# Altium schematic sheet LoRa.SchDoc
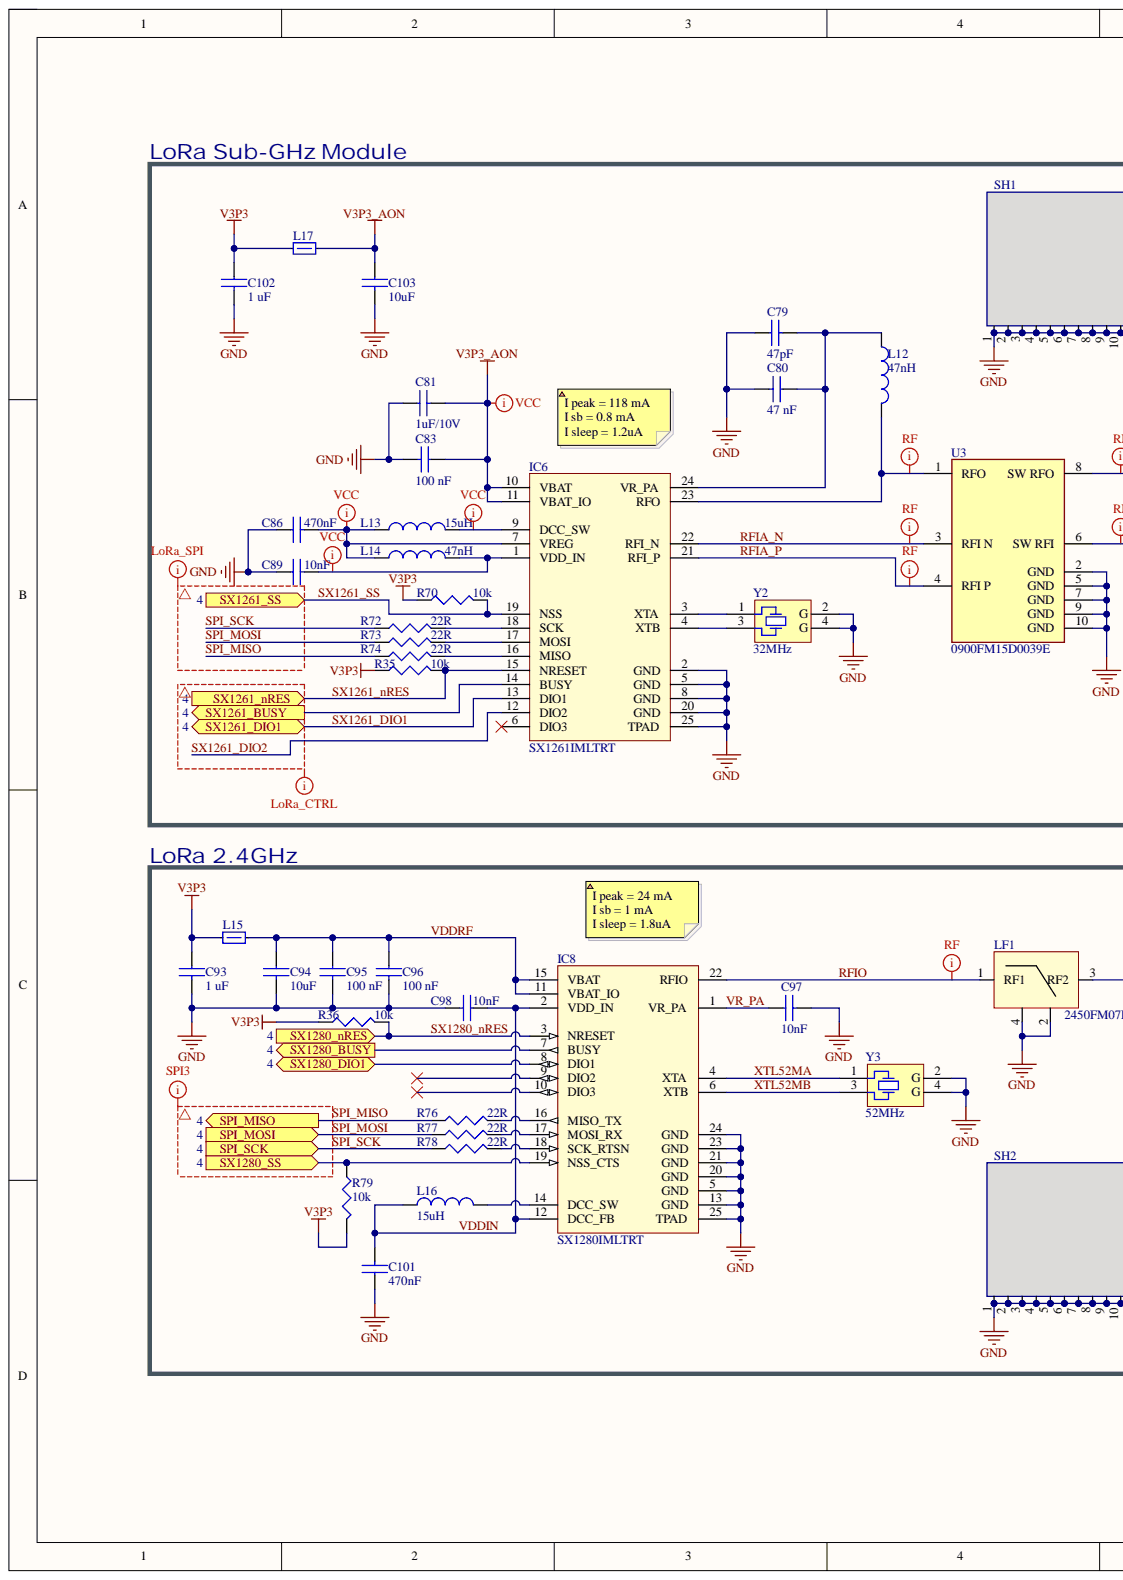
[diagram p0: LoRa.SchDoc, left]
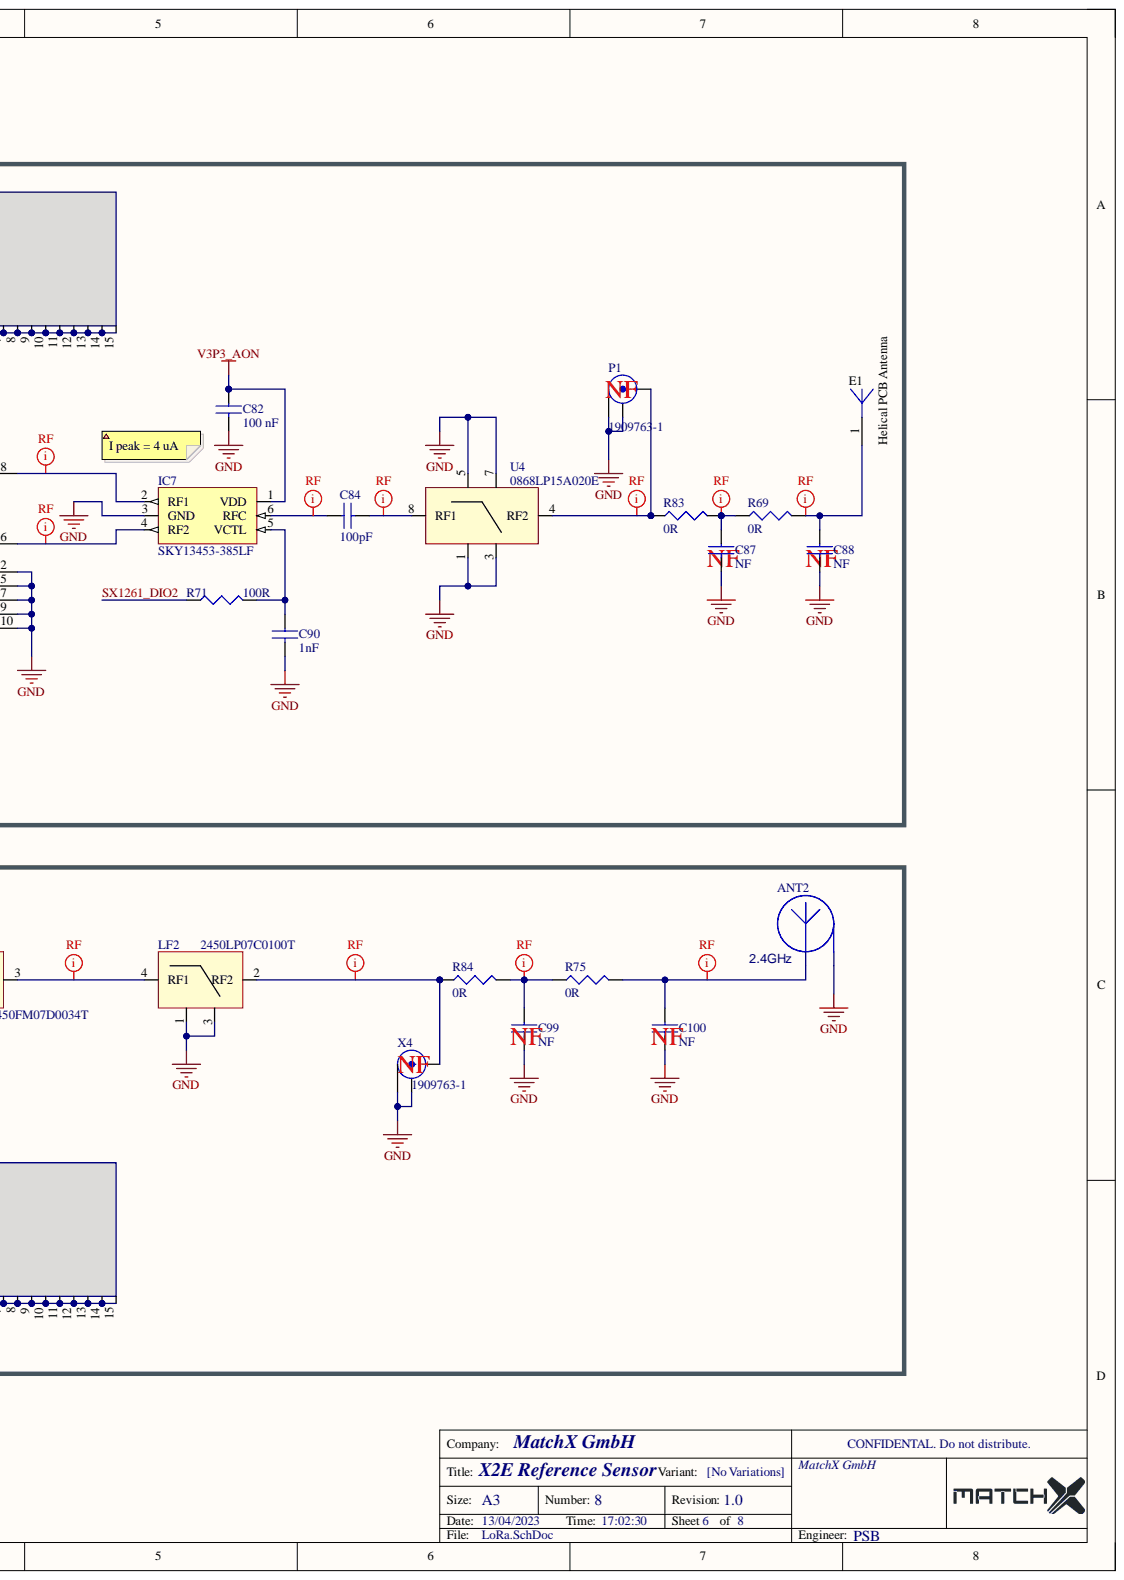
[diagram p1: LoRa.SchDoc, right]

## Parts
ANT2  2.4GHz  [ANT_INVERTED_F]
C79  47pF  [C0402]
C80  47 nF  [C0402]
C81  1uF/10V  [C0402]
C82  100 nF  [C0402]
C83  100 nF  [C0402]
C84  100pF  [C0402]
C86  470nF  [C0402]
C87  NF  [C0402]
C88  NF  [C0402]
C89  10nF  [C0402]
C90  1nF  [C0402]
C93  1 uF  [C0603]
C94  10uF  [C0805]
C95  100 nF  [C0402]
C96  100 nF  [C0402]
C97  10nF  [C0402]
C98  10nF  [C0402]
C99  NF  [C0402]
C100  NF  [C0402]
C101  470nF  [C0402]
C102  1 uF  [C0603]
C103  10uF  [C0805]
E1  Helical PCB Antenna  CompType: Antenna  [ANTENNA_HELICAL]
IC6  SX1261IMLTRT  [QFN50P400X400X80_HS-25N_Semtech]
IC7  SKY13453-385LF  [QFN50P100X100X50-6N]
IC8  SX1280IMLTRT  [QFN50P400X400X80_HS-25N_Semtech]
L12  47nH  [L0402]
L13  15uH  [L0805_ML]
L14  47nH  [L0402]
L15  BLM18KG601SH1D  [L0603]  (value not drawn)
L16  15uH  [L0805_ML]
L17  BLM18KG601SH1D  [L0603]  (value not drawn)
LF1  2450FM07D0034T  [JT=2450FM07D0034T]
LF2  2450LP07C0100T  [JT=2450LP07C0100T]
P1  1909763-1  [CON_U.FL]
R35  10k  [R0402]
R36  10k  [R0402]
R69  0R  .Checked SCH: RF  [R0402]
R70  10k  [R0402]
R71  100R  [R0402]
R72  22R  [R0402]
R73  22R  [R0402]
R74  22R  [R0402]
R75  0R  [R0402]
R76  22R  [R0402]
R77  22R  [R0402]
R78  22R  [R0402]
R79  10k  [R0402]
R83  0R  .Checked SCH: RF  [R0402]
R84  0R  .Checked SCH: RF  [R0402]
SH1  Logo symbol  [SHIELD_MSTICK_SOM]  (value not drawn)
SH2  Logo symbol  [SHIELD_MSTICK_SOM]  (value not drawn)
U3  0900FM15D0039E  [FP-0900FM15D0039E-MFG]
U4  0868LP15A020E  [LP_FILTER_JOHANSON]
X4  1909763-1  [CON_U.FL]
Y2  32MHz  [nd2016da]
Y3  52MHz  [nd2016da]

## Ports
SPI_MISO, SPI_MOSI, SPI_SCK, SX1261_BUSY, SX1261_DIO1, SX1261_nRES, SX1261_SS, SX1280_BUSY, SX1280_DIO1, SX1280_nRES, SX1280_SS

## Nets
GND: ANT2.GND C79.1 C80.2 C81.1 C82.2 C83.2 C86.1 C87.2 C88.2 C89.1 C90.2 C93.2 C94.2 C95.2 C96.2 C97.2 C98.2 C99.2 C100.2 C101.1 C102.2 C103.2 IC6.2(GND) IC6.5(GND) IC6.8(GND) IC6.20(GND) IC6.25(TPAD) IC7.3(GND) IC8.5(GND) IC8.13(GND) IC8.20(GND) IC8.21(GND) IC8.23(GND) IC8.24(GND) IC8.25(TPAD) LF1.2 LF1.4 LF2.1 LF2.3 P1.2 P1.3 SH1.1 SH1.2 SH1.3 SH1.4 SH1.5 SH1.6 SH1.7 SH1.8 SH1.9 SH1.10 SH1.11 SH1.12 SH1.13 SH1.14 SH1.15 SH2.1 SH2.2 SH2.3 SH2.4 SH2.5 SH2.6 SH2.7 SH2.8 SH2.9 SH2.10 SH2.11 SH2.12 SH2.13 SH2.14 SH2.15 U3.2(GND) U3.5(GND) U3.7(GND) U3.9(GND) U3.10(GND) U4.1 U4.3 U4.5 U4.7 X4.2 X4.3 Y2.2(G) Y2.4(G) Y3.2(G) Y3.4(G)
V3P3: C93.1 C102.1 L15.1 L17.1 R35.1 R36.1 R70.1 R79.2
V3P3_AON: C81.2 C82.1 C83.1 C103.1 IC6.10(VBAT) IC6.11(VBAT_IO) IC7.1(VDD) L17.2
RFIA_N: IC6.22(RFI_N) U3.3(RFI_N)
RFIA_P: IC6.21(RFI_P) U3.4(RFI_P)
RFIO: IC8.22(RFIO) LF1.1(RF1)
SPI_MISO: R74.1 R76.1 ; port SPI_MISO
SPI_MOSI: R73.1 R77.1 ; port SPI_MOSI
SPI_SCK: R72.1 R78.1 ; port SPI_SCK
SX1261_BUSY: IC6.14(BUSY) ; port SX1261_BUSY
SX1261_DIO1: IC6.13(DIO1) ; port SX1261_DIO1
SX1261_DIO2: IC6.12(DIO2) R71.1
SX1261_nRES: IC6.15(NRESET) R35.2 ; port SX1261_nRES
SX1261_SS: IC6.19(NSS) R70.2 ; port SX1261_SS
SX1280_BUSY: IC8.7(BUSY) ; port SX1280_BUSY
SX1280_DIO1: IC8.8(DIO1) ; port SX1280_DIO1
SX1280_nRES: IC8.3(NRESET) R36.2 ; port SX1280_nRES
SX1280_SS: IC8.19(NSS_CTS) R79.1 ; port SX1280_SS
VDDIN: C98.1 C101.2 IC8.2(VDD_IN) IC8.12(DCC_FB) L16.1
VDDRF: C94.1 C95.1 C96.1 IC8.11(VBAT_IO) IC8.15(VBAT) L15.2
VR_PA: C97.1 IC8.1(VR_PA)
XTL52MA: IC8.4(XTA) Y3.1
XTL52MB: IC8.6(XTB) Y3.3
NetANT2_RF: ANT2.RF C100.1 R75.2
NetC79_2: C79.2 C80.1 IC6.24(VR_PA) L12.1
NetC84_1: C84.1 IC7.6(RFC)
NetC84_2: C84.2 U4.8(RF1)
NetC86_2: C86.2 IC6.7(VREG) L13.1 L14.1
NetC87_1: C87.1 R69.1 R83.2
NetC88_1: C88.1 E1.1 R69.2
NetC89_2: C89.2 IC6.1(VDD_IN) L14.2
NetC90_1: C90.1 IC7.5(VCTL) R71.2
NetC99_1: C99.1 R75.1 R84.2
NetIC6_3: IC6.3(XTA) Y2.1
NetIC6_4: IC6.4(XTB) Y2.3
NetIC6_9: IC6.9(DCC_SW) L13.2
NetIC6_16: IC6.16(MISO) R74.2
NetIC6_17: IC6.17(MOSI) R73.2
NetIC6_18: IC6.18(SCK) R72.2
NetIC6_23: IC6.23(RFO) L12.2 U3.1(RFO)
NetIC7_2: IC7.2(RF1) U3.8(SW_RFO)
NetIC7_4: IC7.4(RF2) U3.6(SW_RFI)
NetIC8_14: IC8.14(DCC_SW) L16.2
NetIC8_16: IC8.16(MISO_TX) R76.2
NetIC8_17: IC8.17(MOSI_RX) R77.2
NetIC8_18: IC8.18(SCK_RTSN) R78.2
NetLF1_3: LF1.3(RF2) LF2.4(RF1)
NetLF2_2: LF2.2(RF2) R84.1 X4.1
NetP1_1: P1.1 R83.1 U4.4(RF2)

Not connected: IC6 6(DIO3); IC8 9(DIO2) 10(DIO3)

## Library data of the parts
ANT2 = Antenna_IFA_PCB_2.4GHz: Antennas 2.45GHz PCB Meandered; Manufacturer: NA; Manufacturer Part Number: No Part, PCB antenna; Frequency Group: UHF (2 GHz ~ 3 GHz); Frequency Range: 2.408GHz ~ 2.492GHz; Antenna Type: PCB; Applications: Bluetooth, WLAN, Zigbee™; Category: RF/IF and RFID; Component Kind: Net Tie; Features: -; Frequency (Center/Band): 2.4GHz; Height (Max): 0; Ingress Protection: -; Package / Case: PCB; Termination: Solder; Value: 2.4GHz; VSWR: -; Library Name: psblib.SCHLIB
C79 = C0402-47pF: CAP, CERM, 47pF, 16V, +/- 5%, C0G/NP0, 0402; CompType: Capacitor; Category: Capacitors; Component Kind: Standard; MountType: SMT; Operating Temperature: -55°C ~ 125°C; Package / Case: 0402; RoHS: RoHS Compliant; Technology: Ceramic; Temperature Coefficient: C0G/NP0; Tolerance: 5%; Value: 47pF; Voltage - Rated: 16 V; Library Name: psblib.SCHLIB
C80 = C0402-47nF: CAP, CERM, 47nF, 16V, +/- 10%, X7R, 0402; CompType: Capacitor; Category: Capacitors; Component Kind: Standard; MountType: SMT; Operating Temperature: -55°C ~ 125°C; Package / Case: 0402; RoHS: RoHS Compliant; Technology: Ceramic; Temperature Coefficient: X7R; Tolerance: 10%; Value: 47 nF; Voltage - Rated: 16 V; Library Name: psblib.SCHLIB
C81 = C0402-1uF_10V: CAP, CERM, 1 uF, 10V, +/- 10%, X5R, 0402; CompType: Capacitor; Category: Capacitors; Component Kind: Standard; MountType: SMT; Operating Temperature: -55°C ~ 85°C; Package / Case: 0402; RoHS: RoHS Compliant; Technology: Ceramic; Temperature Coefficient: X5R; Tolerance: 10%; Value: 1uF/10V; Voltage - Rated: 10V; Library Name: psblib.SCHLIB
C82 C83 C95 C96 = C0402-100nF_50V: CAP, CERM, 100 nF, 50V, +/- 10%, X7R, 0402; CompType: Capacitor; Category: Capacitors; Component Kind: Standard; MountType: SMT; Operating Temperature: -55°C ~ 125°C; Package / Case: 0402; RoHS: RoHS Compliant; Technology: Ceramic; Temperature Coefficient: X7R; Tolerance: 10%; Value: 100 nF; Voltage - Rated: 50 V; Library Name: psblib.SCHLIB
C84 = C0402-100pF: CAP, CERM, 100pF, 16V, +/- 5%, C0G/NP0, 0402; CompType: Capacitor; Category: Capacitors; Component Kind: Standard; MountType: SMT; Operating Temperature: -55°C ~ 125°C; Package / Case: 0402; RoHS: RoHS Compliant; Technology: Ceramic; Temperature Coefficient: C0G/NP0; Tolerance: 5%; Value: 100pF; Voltage - Rated: 16 V; Library Name: psblib.SCHLIB
C86 C101 = C0402-470nF: CAP, CERM, 470nF, 16V, +/- 10%, X7R, 0402; CompType: Capacitor; Category: Capacitors; Component Kind: Standard; MountType: SMT; Operating Temperature: -55°C ~ 125°C; Package / Case: 0402; RoHS: RoHS Compliant; Technology: Ceramic; Temperature Coefficient: X7R; Tolerance: 10%; Value: 470nF; Voltage - Rated: 16 V; Library Name: psblib.SCHLIB
C87 C88 C99 C100 = C0402-1.8pF: CAP, CERM, 1.8pF, 50V, +/- 0.1pF, C0G/NP0, 0402; CompType: Capacitor; Category: Capacitors; Component Kind: Standard; MountType: SMT; Operating Temperature: -55°C ~ 125°C; Package / Case: 0402; RoHS: RoHS Compliant; Technology: Ceramic; Temperature Coefficient: C0G/NP0; Tolerance: +/- 0.1pF; Value: NF; Voltage - Rated: 50V; Library Name: psblib.SCHLIB
C89 C97 C98 = C0402-10nF: CAP, CERM, 10nF, 16V, +/- 10%, X7R, 0402; CompType: Capacitor; Category: Capacitors; Component Kind: Standard; MountType: SMT; Operating Temperature: -55°C ~ 125°C; Package / Case: 0402; RoHS: RoHS Compliant; Technology: Ceramic; Temperature Coefficient: X7R; Tolerance: 10%; Value: 10nF; Voltage - Rated: 16 V; Library Name: psblib.SCHLIB
C90 = C0402-1nF: CAP, CERM, 1nF, 16V, +/- 10%, X7R, 0402; CompType: Capacitor; Category: Capacitors; Component Kind: Standard; MountType: SMT; Operating Temperature: -55°C ~ 125°C; Package / Case: 0402; RoHS: RoHS Compliant; Technology: Ceramic; Temperature Coefficient: X7R; Tolerance: 10%; Value: 1nF; Voltage - Rated: 16 V; Library Name: psblib.SCHLIB
C93 C102 = C0603-1uF_25V: CAP, CERM, 1 uF, 25 V, +/- 10%, X7R, 0603; CompType: Capacitor; Voltage - Rated: 25 V; Category: Capacitors; Component Kind: Standard; MountType: SMT; Operating Temperature: -55°C ~ 125°C; Package / Case: 0603 (1608 Metric); RoHS: RoHS Compliant; Technology: Ceramic; Temperature Coefficient: X7R; Tolerance: 10%; Value: 1 uF; Library Name: psblib.SCHLIB
C94 C103 = C0805-10uF_16V: CAP, CERM, 10uF, 16V, +/- 20%, X5R, 0805; CompType: Capacitor; Category: Capacitors; Component Kind: Standard; MountType: SMT; Operating Temperature: -55°C ~ 85°C; Package / Case: 0805 (2012 Metric); RoHS: RoHS Compliant; Technology: Ceramic; Temperature Coefficient: X5R; Tolerance: 20%; Value: 10uF; Voltage - Rated: 16 V; Library Name: psblib.SCHLIB
E1 = ANTENNA_HELICAL: PCB Antenna. There is nothing to buy or mount.; Manufacturer Part Number: PCB Antenna, No Part; SymbolCreator: Altium; Component Kind: Net Tie; ComponentLink1Description: Datasheet Link; ComponentLink1URL: http://www.ti.com/lit/an/swra416/swra416.pdf; LatestRevisionDate: 2015-04-23; Manufacturer: N/A; MountType: N/A; PackageReference: Antenna; PartNumber: Helical PCB Antenna; SymbolVersion: 2015-04-22; Library Name: psblib.SCHLIB; Publisher: Texas Instruments
IC6 = SX1261IMLTRT: IC RF TxRx Only General ISM < 1GHz LoRaWAN 150MHz ~ 960MHz 24-VFQFN Exposed Pad; Manufacturer: Semtech; Component Kind: Standard; ComponentLink1Description: https://www.semtech.com/uploads/documents/DS_SX1261-2_V1.1.pdf?src-supplier=Digi-Key; ComponentLink1URL: https://www.semtech.com/uploads/documents/DS_SX1261-2_V1.1.pdf?src-supplier=Digi-Key; Manufacturer Part Number: SX1261IMLTRT; Pricing 1: 1 = 7,53000, 10 = 6,90700, 25 = 6,27920, 100 = 5,65110, 250 = 5,18016, 500 = 4,70926, 1000 = 4,23833 (USD); RoHS: No; Stock 1: 1035; Supplier 1: Digi-Key; Supplier Part Number 1: SX1261IMLTRTCT-ND; Library Name: psblib.SCHLIB
IC7 = SKY13453-385LF: RF Switch IC WLAN 6GHz 50 Ohm 6-QFN (1x1); Manufacturer: Skyworks Solutions; IIP3: 57dBm; Impedance: 50R; Max Frequency: 600MHz; Operating Supply Voltage: 3.5V; P1dB: 33dBm; Case/Package: QFN; Component Kind: Standard; ComponentLink1Description: http://www.mouser.com/ds/2/472/SKY13453_385LF_202830B-437415.pdf?src-supplier=Mouser; ComponentLink1URL: http://www.mouser.com/ds/2/472/SKY13453_385LF_202830B-437415.pdf?src-supplier=Mouser; ComponentLink2Description: http://www.skyworksinc.com/uploads/documents/SKY13453_385LF_202830C.pdf?src-supplier=Avnet+Asia; ComponentLink2URL: http://www.skyworksinc.com/uploads/documents/SKY13453_385LF_202830C.pdf?src-supplier=Avnet+Asia; ComponentLink3Description: http://www.skyworksinc.com/uploads/documents/SKY13453_385LF_202830E.pdf?src-supplier=Digi-Key; ComponentLink3URL: http://www.skyworksinc.com/uploads/documents/SKY13453_385LF_202830E.pdf?src-supplier=Digi-Key; ComponentLink4Description: http://www.skyworksinc.com/uploads/documents/SKY13453_385LF_202830C.pdf?src-supplier=Avnet; ComponentLink4URL: http://www.skyworksinc.com/uploads/documents/SKY13453_385LF_202830C.pdf?src-supplier=Avnet; Manufacturer Part Number: SKY13453-385LF; Max Operating Temperature: 90°C; Min Operating Temperature: -30°C; Mount: Surface Mount; Number of Pins: 6; Packaging: Tape and Reel; Pricing 1: 1 = 0,63000, 10 = 0,55700, 100 = 0,42740, 500 = 0,33784, 1000 = 0,27027 (USD); RoHS: Yes; Stock 1: 46443; Supplier 1: Digi-Key; Supplier Part Number 1: 863-1602-1-ND; Library Name: MatchX_Integrated_Library.IntLib
IC8 = SX1280IMLTRT: IC RF TxRx Only 802.15.4 LoRa™ 2.4GHz 24-WFQFN Exposed Pad; Manufacturer: Semtech; Component Kind: Standard; ComponentLink1Description: https://www.semtech.com/uploads/documents/DS_SX1280-1_V2.2.pdf?src-supplier=Digi-Key; ComponentLink1URL: https://www.semtech.com/uploads/documents/DS_SX1280-1_V2.2.pdf?src-supplier=Digi-Key; Manufacturer Part Number: SX1280IMLTRT; Pricing 1: 1=6,93000, 10=6,35300, 25=5,77520, 100=5,19750, 250=4,76436, 500=4,33126, 1000=3,89813 (USD); RoHS: No; Stock 1: 1571; Supplier 1: Digi-Key; Supplier Part Number 1: SX1280IMLTRTCT-ND; Library Name: psblib.SCHLIB
L12 L14 = LQW15AN47NJ00D: Inductor, Wirewound, 47nH, 210 mA, 1.08Ohms, ±0.2nH, SMD; Manufacturer: Murata; Core Material: Air; Current Rating: 210mA; DC Resistance (DCR): 1.08R; Q Factor: 25; Self Resonant Frequency: 2.9GHz; Shielding: Unshielded; Test Frequency: 100MHz; Tolerance: 5%; Case Code (Imperial): 0402; Case Code (Metric): 1005; Case/Package: 0402; Component Kind: Standard; ComponentLink1Description: https://datasheet.ciiva.com/11799/0900766b8090d800-11799049.pdf?src-supplier=RSComponents; ComponentLink1URL: https://datasheet.ciiva.com/11799/0900766b8090d800-11799049.pdf?src-supplier=RSComponents; ComponentLink2Description: https://datasheet.ciiva.com/1042/l0075s0080lqw15a-00-1042160.pdf?src-supplier=Digi-Key; ComponentLink2URL: https://datasheet.ciiva.com/1042/l0075s0080lqw15a-00-1042160.pdf?src-supplier=Digi-Key; ComponentLink3Description: https://datasheet.ciiva.com/1042/l0075s0080lqw15a-00-1042161.pdf?src-supplier=Digi-Key; ComponentLink3URL: https://datasheet.ciiva.com/1042/l0075s0080lqw15a-00-1042161.pdf?src-supplier=Digi-Key; ComponentLink4Description: https://datasheet.ciiva.com/8506/l0075s0080lqw15a-00-8506009.pdf?src-supplier=Avnet; ComponentLink4URL: https://datasheet.ciiva.com/8506/l0075s0080lqw15a-00-8506009.pdf?src-supplier=Avnet; ComponentLink5Description: https://datasheet.ciiva.com/17247/l0075s0080lqw15a-00-17247726.pdf?src-supplier=Avnet+Europe; ComponentLink5URL: https://datasheet.ciiva.com/17247/l0075s0080lqw15a-00-17247726.pdf?src-supplier=Avnet+Europe; ComponentLink6Description: https://datasheet.ciiva.com/1042/l0075s0080lqw15a-00-1042159.pdf?src-supplier=Digi-Key; ComponentLink6URL: https://datasheet.ciiva.com/1042/l0075s0080lqw15a-00-1042159.pdf?src-supplier=Digi-Key; Composition: Wirewound; DC Current: 210mA; Depth: 0.6mm; Height: 0.5mm; Inductance: 47nH; Lead Free: Lead Free; Length: 1mm; Manufacturer Part Number: LQW15AN47NJ00D; Max DC Current: 210mA; Max Operating Temperature: 125°C; Military Standard: Not; Min Operating Temperature: -55°C; Mount: Surface Mount; Package Quantity: 1; Packaging: Tape and Reel; Pricing 1: 1 = 0,17000, 10 = 0,15500, 100 = 0,10350, 500 = 0,08626, 1000 = 0,07245, 2500 = 0,06210 (USD); Radiation Hardening: No; RoHS: Yes; Signal Integrity: Inductor; Simulation: Inductor; Stock 1: 62730; Supplier 1: Digi-Key; Supplier Part Number 1: 490-1154-1-ND; Termination: SMD/SMT; Value: 47nH; Weight: 2.8E-05oz; Width: 0.02inch; Library Name: psblib.SCHLIB
L13 = L_LQM21DN150M70L: Inductor, 15Uh, 0.25A, Multilayer Rohs Compliant: Yes; Manufacturer: Murata; Category: Fixed Inductors; Component Kind: Standard; Manufacturer Part Number: LQM21DN150M70L; Pricing 1: 1=0,26000, 10=0,23700, 25=0,21040, 50=0,17880, 100=0,15770, 250=0,14460, 500=0,13144, 1000=0,11042 (USD); RoHS: Yes; Stock 1: 2488; Supplier 1: Digi-Key; Supplier Part Number 1: 490-LQM21DN150M70LCT-ND; Value: 15uH; Library Name: psblib.SCHLIB
L15 L17 = BLM18KG601SH1D: Ferrite Beads 0603 600ohms 1.3A DCR=.15ohms; Manufacturer: Murata Electronics North America; DC Resistance (DCR) (Max): 150 mOhm; Filter Type: Power Line; Impedance @ Frequency: 600 Ohm @ 100MHz; Number of Lines: 1; Category: Filters; Component Kind: Standard; ComponentLink1Description: http://www.murata.com/en-global/products/productdata/8796737273886/QNFA9101.pdf; ComponentLink1URL: http://www.murata.com/en-global/products/productdata/8796737273886/QNFA9101.pdf; Current Rating (Max): 1.3A; Height (Max): 0.037" (0.95mm); Manufacturer Part Number: BLM18KG601SH1D; Mounting Type: Surface Mount; Operating Temperature: -55°C ~ 125°C; Package / Case: 0603 (1608 Metric); Packaging: Cut Tape (CT); Pricing 1: 1=0.11, 10=0.088, 50=0.058, 100=0.0502, 250=0.0396, 500=0.03344, 1000=0.02816 (USD); Ratings: AEC-Q200; RoHS: RoHS Compliant; Size / Dimension: 0.063" L x 0.032" W (1.60mm x 0.80mm); Stock 1: 5830; Supplier 1: Digi-Key; Supplier Part Number 1: 490-13259-1-ND; Library Name: psblib.SCHLIB
L16 = L_MLZ2012M150WTD25: Chip inductor, 15uH, 250mA, 950mOhm, 0805; Manufacturer: TDK; Core Material: Ferrite; DC Resistance (DCR): 1.235R; Saturation Current: 120mA; Shielding: Shielded; Test Frequency: 2MHz; Tolerance: 20%; Case Code (Imperial): 0805; Case Code (Metric): 2012; Case/Package: 0805; Component Kind: Standard; ComponentLink1Description: http://www.mouser.com/ds/2/400/nductor_commercial_decoupling_mlz2012_en-918301.pdf?src-supplier=Mouser; ComponentLink1URL: http://www.mouser.com/ds/2/400/nductor_commercial_decoupling_mlz2012_en-918301.pdf?src-supplier=Mouser; ComponentLink2Description: http://product.tdk.com/inductor/ind/en/documents/inductor_automotive_decoupling_mlz_en.pdf?src-supplier=Digi-Key; ComponentLink2URL: http://product.tdk.com/inductor/ind/en/documents/inductor_automotive_decoupling_mlz_en.pdf?src-supplier=Digi-Key; ComponentLink3Description: https://product.tdk.com/info/en/catalog/datasheets/inductor_automotive_decoupling_mlz2012_en.pdf?src-supplier=Digi-Key; ComponentLink3URL: https://product.tdk.com/info/en/catalog/datasheets/inductor_automotive_decoupling_mlz2012_en.pdf?src-supplier=Digi-Key; Current Rating: 250mA; Height: 1.25mm; Height - Seated (Max): 0.057inch; Inductance: 15uH; Length: 0.079inch; Manufacturer Part Number: MLZ2012M150WTD25; Max DC Current: 250mA; Max Operating Temperature: 125°C; Min Operating Temperature: -55°C; Mount: Surface Mount; Package Quantity: 2000; Packaging: Tape and Reel; Pricing 1: 1 = 0,19000, 10 = 0,16800, 100 = 0,11210, 500 = 0,09346, 1000 = 0,07850 (USD); Ratings: AEC-Q200; RoHS: Yes; Stock 1: 1570; Supplier 1: Digi-Key; Supplier Part Number 1: 445-17074-1-ND; Termination: SMD/SMT; Value: 15uH; Weight: 0.000194oz; Width: 0.049inch; Library Name: psblib.SCHLIB
LF1 = JT=2450FM07D0034T: Rf Filter 2.4GHZ-2.5GHZ 0402; Manufacturer: Johanson; Bandwidth: 100MHz; Frequency: 2.5GHz; Insertion Loss: 0.75dB; Case Code (Imperial): 0402; Case Code (Metric): 1005; Component Kind: Standard; ComponentLink1Description: https://www.johansontechnology.com/datasheets/2450FM07D0034/2450FM07D0034.pdf?src-supplier=Digi-Key; ComponentLink1URL: https://www.johansontechnology.com/datasheets/2450FM07D0034/2450FM07D0034.pdf?src-supplier=Digi-Key; Manufacturer Part Number: 2450FM07D0034T; Mount: Surface Mount; Packaging: Tape and Reel; Pricing 1: 1=0,28000, 10=0,24400, 25=0,22080, 100=0,19310, 250=0,16944, 500=0,14972, 1000=0,11820 (USD); RoHS: Yes; RoHS Compliant: No; Stock 1: 116294; Supplier 1: Digi-Key; Supplier Part Number 1: 712-1654-1-ND; Library Name: psblib.SCHLIB
LF2 = JT=2450LP07C0100T: Rf Filter Low Pass 2.4GHZ 0402; Manufacturer: Johanson; Component Kind: Standard; Manufacturer Part Number: 2450LP07C0100T; Pricing 1: 1=0,29000, 10=0,26900, 25=0,25640, 50=0,24800, 100=0,23980, 250=0,22736, 500=0,19016, 1000=0,14758, 5000=0,13229 (USD); RoHS: No; Stock 1: 17511; Supplier 1: Digi-Key; Supplier Part Number 1: 712-2450LP07C0100TCT-ND; Library Name: psblib.SCHLIB
P1 = CON_U.FL: CONN UMCC JACK STR 50OHM SMD; Manufacturer: TE Connectivity AMP Connectors; Body Finish: Gold; Body Material: Copper Alloy; Connector Style: Ultra Miniature Coaxial; Connector Type: Jack, Male Pin; Contact Termination: Solder; Dielectric Material: Liquid Crystal Polymer (LCP); Frequency - Max: 6GHz; Includes: 1 pc - 1 Connector; Insertion Loss: 0.24dB; Mating Cycles: 30; Voltage Rating: 60V; Cable Group: -; Category: Connectors, Interconnects; Center Contact Material: Copper Alloy; Center Contact Plating: Gold; Component Kind: Standard; ComponentLink1Description: http://www.te.com/commerce/DocumentDelivery/DDEController?Action=srchrtrv&DocNm=1909763&DocType=Customer+Drawing&DocLang=English; ComponentLink1URL: http://www.te.com/commerce/DocumentDelivery/DDEController?Action=srchrtrv&DocNm=1909763&DocType=Customer+Drawing&DocLang=English; Fastening Type: Snap-On; Features: -; Housing Color: Ivory; Impedance: 50 Ohm; Ingress Protection: -; Manufacturer Part Number: 1909763-1; Mounting Feature: -; Mounting Type: Surface Mount; Operating Temperature: -40°C ~ 90°C; Packaging: Cut Tape (CT); Part Status: Active; Pricing 1: 1=0.54, 10=0.508, 25=0.4572, 50=0.4066, 100=0.3896, 250=0.35576, 500=0.3388, 1000=0.27951, 2500=0.2541 (USD); RoHS: RoHS Compliant; Shield Termination: Solder; Stock 1: 68653; Supplier 1: Digi-Key; Supplier Part Number 1: A118077CT-ND; Library Name: psblib.SCHLIB
R35 R36 R70 R79 = R0402-10k: RES, 10k, 1%, 1/16 W, 0402; .Checked PCB: OK RF; .Checked SCH: RF; Power (Watts): 0.063W, 1/16W; Category: Resistors; Component Kind: Standard; Composition: Thick Film; Features: -; Height: 0.016" (0.40mm); Number of Terminations: 2; Package / Case: 0402; RoHS: RoHS Compliant; Size / Dimension: 0.039" L x 0.020" W (1.00mm x 0.50mm); Tolerance: ±1%; Value: 10k; Library Name: psblib.SCHLIB
R69 R83 R84 = R0402-0R: RES, 0R, 1%, 1/16 W, 0402; .Checked PCB: OK RF; Power (Watts): 0.063W, 1/16W; Category: Resistors; Component Kind: Standard; Composition: Thick Film; Features: -; Height: 0.016" (0.40mm); Number of Terminations: 2; Package / Case: 0402; RoHS: RoHS Compliant; Size / Dimension: 0.039" L x 0.020" W (1.00mm x 0.50mm); Tolerance: ±1%; Value: 0R; Library Name: psblib.SCHLIB
R71 = R0402-100R: RES, 100R, 1%, 1/16 W, 0402; .Checked PCB: OK RF; .Checked SCH: RF; Power (Watts): 0.063W, 1/16W; Category: Resistors; Component Kind: Standard; Composition: Thick Film; Features: -; Height: 0.016" (0.40mm); Number of Terminations: 2; Package / Case: 0402; RoHS: RoHS Compliant; Size / Dimension: 0.039" L x 0.020" W (1.00mm x 0.50mm); Tolerance: ±1%; Value: 100R; Library Name: psblib.SCHLIB
R72-R74 R76-R78 = R0402-22R: RES, 22R, 1%, 1/16 W, 0402; .Checked PCB: OK RF; .Checked SCH: RF; Power (Watts): 0.063W, 1/16W; Category: Resistors; Component Kind: Standard; Composition: Thick Film; Features: -; Height: 0.016" (0.40mm); Number of Terminations: 2; Package / Case: 0402; RoHS: RoHS Compliant; Size / Dimension: 0.039" L x 0.020" W (1.00mm x 0.50mm); Tolerance: ±1%; Value: 22R; Library Name: psblib.SCHLIB
R75 = R0402-0R: RES, 0R, 1%, 1/16 W, 0402; .Checked PCB: OK RF; .Checked SCH: RF; Power (Watts): 0.063W, 1/16W; Category: Resistors; Component Kind: Standard; Composition: Thick Film; Features: -; Height: 0.016" (0.40mm); Number of Terminations: 2; Package / Case: 0402; RoHS: RoHS Compliant; Size / Dimension: 0.039" L x 0.020" W (1.00mm x 0.50mm); Tolerance: ±1%; Value: 0R; Library Name: psblib.SCHLIB
SH1 SH2 = Shield_MStick_SOM: Shield - EMI Gasket - Standard Surface Mount Shields 14.9x18.5x2mm; Component Kind: Standard; Package / Case: 14.9x18.5x2mm; Library Name: psblib.SCHLIB
U3 = 0900FM15D0039E: Impedance-matched Filter For Sem; Manufacturer: Johanson; Category: EMI / RFI Components; Component Kind: Standard; Manufacturer Part Number: 0900FM15D0039E; Pricing 1: 1=0,50000, 10=0,43000, 50=0,43000, 100=0,38800, 1000=0,24900, 10000=0,21000 (USD); RoHS: Yes; Stock 1: 155361; Supplier 1: Mouser; Supplier Part Number 1: 609-0900FM15D0039E; Library Name: psblib.SCHLIB
U4 = LP_0868LP15A020E: RF Filter - Lowpass; Manufacturer: Johanson; Brand: Johanson Technology; Frequency: 868 MHz; Frequency Range: 858 MHz to 878 MHz; Impedance: 50 Ohms; Insertion Loss: 0.5 dB; Component Kind: Standard; ComponentLink1Description: https://datasheet.ciiva.com/24047/lp-filter-0868lp15a020-258169-24047630.pdf; ComponentLink1URL: https://datasheet.ciiva.com/24047/lp-filter-0868lp15a020-258169-24047630.pdf; ComponentLink2Description: https://datasheet.ciiva.com/7662/1640998-7662948.pdf; ComponentLink2URL: https://datasheet.ciiva.com/7662/1640998-7662948.pdf; Factory Pack Quantity: 4000; LatestRevisionDate: 05-oct-2007  -  Semtech; Manufacturer Part Number: 0868LP15A020E; Operating Temperature Range: - 40 C to + 85 C; Package / Case: 0805 (2012 metric); Packaging: Reel; Pricing 1: 1=0.64, 10=0.614, 25=0.594, 50=0.4852, 100=0.4356, 250=0.396, 500=0.3762, 1000=0.3366 (USD); Product: Low Pass Filters; Product Category: Signal Conditioning; RoHS: Details; Stock 1: 13811; Supplier 1: Digi-Key; Supplier Part Number 1: 712-1585-1-ND; Termination Style: SMD/SMT; Type: High Frequency Ceramic; Library Name: psblib.SCHLIB
X4 = CON_U.FL: CONN UMCC JACK STR 50OHM SMD; Manufacturer: TE Connectivity AMP Connectors; .Checked PCB: OK M; Body Finish: Gold; Body Material: Copper Alloy; Connector Style: Ultra Miniature Coaxial; Connector Type: Jack, Male Pin; Contact Termination: Solder; Dielectric Material: Liquid Crystal Polymer (LCP); Frequency - Max: 6GHz; Includes: 1 pc - 1 Connector; Insertion Loss: 0.24dB; Mating Cycles: 30; Voltage Rating: 60V; Cable Group: -; Category: Connectors, Interconnects; Center Contact Material: Copper Alloy; Center Contact Plating: Gold; Component Kind: Standard; ComponentLink1Description: http://www.te.com/commerce/DocumentDelivery/DDEController?Action=srchrtrv&DocNm=1909763&DocType=Customer+Drawing&DocLang=English; ComponentLink1URL: http://www.te.com/commerce/DocumentDelivery/DDEController?Action=srchrtrv&DocNm=1909763&DocType=Customer+Drawing&DocLang=English; Fastening Type: Snap-On; Features: -; Housing Color: Ivory; Impedance: 50 Ohm; Ingress Protection: -; Manufacturer Part Number: 1909763-1; Mounting Feature: -; Mounting Type: Surface Mount; Operating Temperature: -40°C ~ 90°C; Packaging: Cut Tape (CT); Part Status: Active; Pricing 1: 1=0.54, 10=0.508, 25=0.4572, 50=0.4066, 100=0.3896, 250=0.35576, 500=0.3388, 1000=0.27951, 2500=0.2541 (USD); RoHS: RoHS Compliant; Shield Termination: Solder; Stock 1: 68653; Supplier 1: Digi-Key; Supplier Part Number 1: A118077CT-ND; .Checked SCH: OK M; Library Name: psblib.SCHLIB
Y2 = XTAL_ECS-320-10-37B-CKY: 32MHz ±10ppm Crystal 10pF 50 Ohms 4-SMD 2016, No Lead; Manufacturer: ECS International; Component Kind: Standard; Manufacturer Part Number: ECS-320-10-37B-CKY; Pricing 1: 1=0.5, 10=0.415, 50=0.3738, 100=0.3322, 500=0.3156 (USD); Stock 1: 1000; Supplier 1: Digikey; Supplier Part Number 1: XC3068DKR-ND; Value: 32MHz; Library Name: psblib.SCHLIB
Y3 = XTAL_NX2016SA-52MHZ-EXS00A-CS07103: 52 MHz Crystal 10pF 50 Ohms 4-SMD, No Lead; Manufacturer: NDK; ESR (Equivalent Series Resistance): 50R; Case/Package: SMD/SMT; Component Kind: Standard; Frequency: 52MHz; Load Capacitance: 10pF; Manufacturer Part Number: NX2016SA-52MHZ-EXS00A-CS07103; Max Operating Temperature: 125°C; Min Operating Temperature: -40°C; Mount: Surface Mount; Packaging: Tape and Reel; Pricing 1: 1=0,79000, 10=0,69700, 50=0,65140, 100=0,57570, 500=0,54540, 1000=0,45450 (USD); Ratings: AEC-Q200; RoHS: No; Stock 1: 59845; Supplier 1: Digi-Key; Supplier Part Number 1: 644-1381-1-ND; Value: 52MHz; Library Name: psblib.SCHLIB
